# Altium schematic sheet sdrtckart.SchDoc
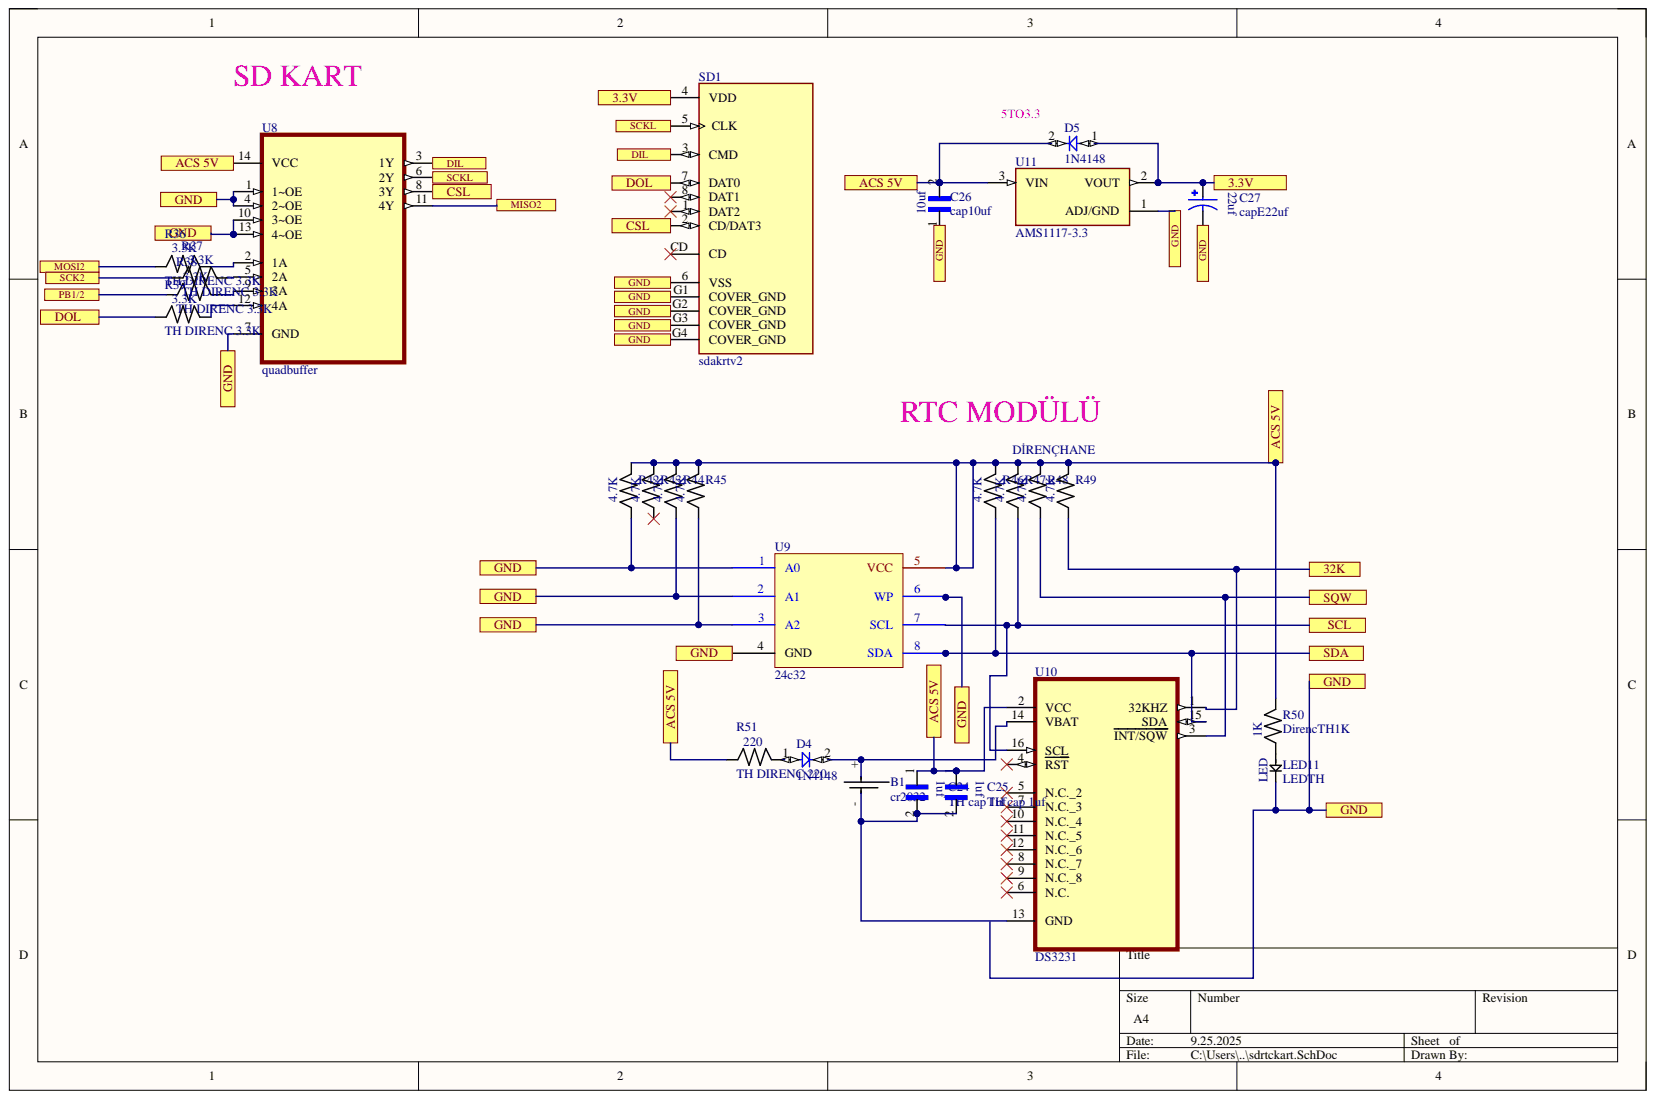
[diagram p0: sdrtckart.SchDoc]

## Parts
B1  cr2032  [vertical battery holder]
C24  TH cap 1uf  [Kapkucuk]
C25  TH cap 1uf  [Kapkucuk]
C26  cap10uf  [1206cap]
C27  capE22uf  [4x7 CAP]
D4  1N4148  [1N4148]
D5  1N4148  [1N4148]
LED11  LEDTH  [led3mm]
R36  TH DIRENC 3.3K  [resistor TH]
R37  TH DIRENC 3.3K  [resistor TH]
R38  TH DIRENC 3.3K  [resistor TH]
R39  TH DIRENC 3.3K  [resistor TH]
R42  TH Direnç 4.7K  [resistor TH]  (value not drawn)
R43  TH Direnç 4.7K  [resistor TH]  (value not drawn)
R44  TH Direnç 4.7K  [resistor TH]  (value not drawn)
R45  TH Direnç 4.7K  [resistor TH]  (value not drawn)
R46  TH Direnç 4.7K  [resistor TH]  (value not drawn)
R47  TH Direnç 4.7K  [resistor TH]  (value not drawn)
R48  TH Direnç 4.7K  [resistor TH]  (value not drawn)
R49  TH Direnç 4.7K  [resistor TH]  (value not drawn)
R50  DirencTH1K  [resistor TH]
R51  TH DIRENC 220  [resistor TH]
SD1  sdakrtv2  [sdkartv2]
U8  quadbuffer  [dip 14]
U9  24c32  [dip8]
U10  DS3231  [soic16]
U11  AMS1117-3.3  [v3.3 footprint]

## Ports
3.3V, 32K, ACS 5V, CSL, DIL, DOL, GND, MISO2, MOSI2, PB1/2, SCK2, SCKL, SCL, SDA, SQW

## Nets
3.3V: C27.P D5.1 SD1.4(VDD) U11.2 ; port 3.3V
32K: R49.0 U10.1(32KHZ) ; port 32K
ACS 5V: C24.1 C25.1 C26.2 D5.2 R42.1 R43.1 R44.1 R45.1 R46.1 R47.1 R48.1 R49.1 R50.1 R51.0 U8.14(VCC) U9.5(VCC) U10.2(VCC) U11.3 ; port ACS 5V
CSL: SD1.2(CD/DAT3) U8.8(3Y) ; port CSL
DIL: SD1.3(CMD) U8.3(1Y) ; port DIL
DOL: R39.0 SD1.7(DAT0) ; port DOL
GND: C26.1 C27.N LED11.1 R42.0 R44.0 R45.0 SD1.6(VSS) SD1.G1(COVER_GND) SD1.G2(COVER_GND) SD1.G3(COVER_GND) SD1.G4(COVER_GND) U8.1(1~OE) U8.4(2~OE) U8.7(GND) U8.10(3~OE) U8.13(4~OE) U9.1(A0) U9.2(A1) U9.3(A2) U9.4(GND) U9.6(WP) U11.1 ; port GND
MISO2: U8.11(4Y) ; port MISO2
MOSI2: R36.0 ; port MOSI2
PB1/2: R38.0 ; port PB1/2
SCK2: R37.0 ; port SCK2
SCKL: SD1.5(CLK) U8.6(2Y) ; port SCKL
SCL: R47.0 U10.16(SCL) ; port SCL
SDA: R46.0 U9.8(SDA) U10.15(SDA) ; port SDA
SQW: R48.0 U10.3(INT/SQW) ; port SQW
NetB1_+: B1.+ D4.2 U10.14(VBAT)
NetB1_-: B1.- C24.2 C25.2 U10.13(GND)
NetD4_1: D4.1 R51.1
NetLED11_0: LED11.0 R50.0
NetR36_1: R36.1 U8.2(1A)
NetR37_1: R37.1 U8.5(2A)
NetR38_1: R38.1 U8.9(3A)
NetR39_1: R39.1 U8.12(4A)

Not connected: R43 0; SD1 1(DAT2) 8(DAT1) CD; U9 7(SCL); U10 4(RST) 5(N.C._2) 6(N.C.) 7(N.C._3) 8(N.C._7) 9(N.C._8) 10(N.C._4) 11(N.C._5) 12(N.C._6)

## Library data of the parts
SD1 = sdakrtv2: Micro SD Card Connector, 9 Positions, Connector and Ejector, Push In, Auto Eject Out, Surface Mount, 1.8 mm Height Above Board, Gold Flash; MF: Same Sky; MP: MSD-1-A; Check_prices: https://www.snapeda.com/parts/MSD-1-A/Same+Sky/view-part/?ref=eda; Component Kind: Standard; Library Name: Copy of Parts.SchLib; Package: None; SnapEDA_Link: https://www.snapeda.com/parts/MSD-1-A/Same+Sky/view-part/?ref=snap
U11 = AMS1117-3.3: Linear Voltage Regulator IC Positive Fixed 1 Output 1A SOT-223-3L; MF: UMW; Check_prices: https://www.snapeda.com/parts/AMS1117-3.3/UMW/view-part/?ref=eda; Component Kind: Standard; Library Name: Copy of Parts.SchLib; MP: AMS1117-3.3; Package: SOT-223-3L UMW; SnapEDA_Link: https://www.snapeda.com/parts/AMS1117-3.3/UMW/view-part/?ref=snap
B1 = cr2032: Component Kind: Standard; Library Name: Copy of Parts.SchLib
C24 C25 = TH cap 1uf: Component Kind: Standard; Library Name: Copy of Parts.SchLib
C26 = cap10uf: Component Kind: Standard; Library Name: Copy of Parts.SchLib
C27 = capE22uf: Component Kind: Standard; Library Name: Copy of Parts.SchLib
D4 D5 = 1N4148: Component Kind: Standard; Library Name: Copy of Parts.SchLib
LED11 = LEDTH: Component Kind: Standard; Library Name: Copy of Parts.SchLib
R36-R39 = TH DIRENC 3.3K: Component Kind: Standard; Library Name: Copy of Parts.SchLib
R42-R49 = TH Direnç 4.7K: Component Kind: Standard; Library Name: Copy of Parts.SchLib
R50 = DirencTH1K: Component Kind: Standard; Library Name: Copy of Parts.SchLib
R51 = TH DIRENC 220: Component Kind: Standard; Library Name: Copy of Parts.SchLib
U8 = quadbuffer: Component Kind: Standard; Library Name: Copy of Parts.SchLib
U9 = 24c32: Component Kind: Standard; Library Name: Copy of Parts.SchLib
U10 = DS3231: Component Kind: Standard; Library Name: Copy of Parts.SchLib
